# Altium schematic sheet 04fpga-io.SchDoc(04fpga-io)
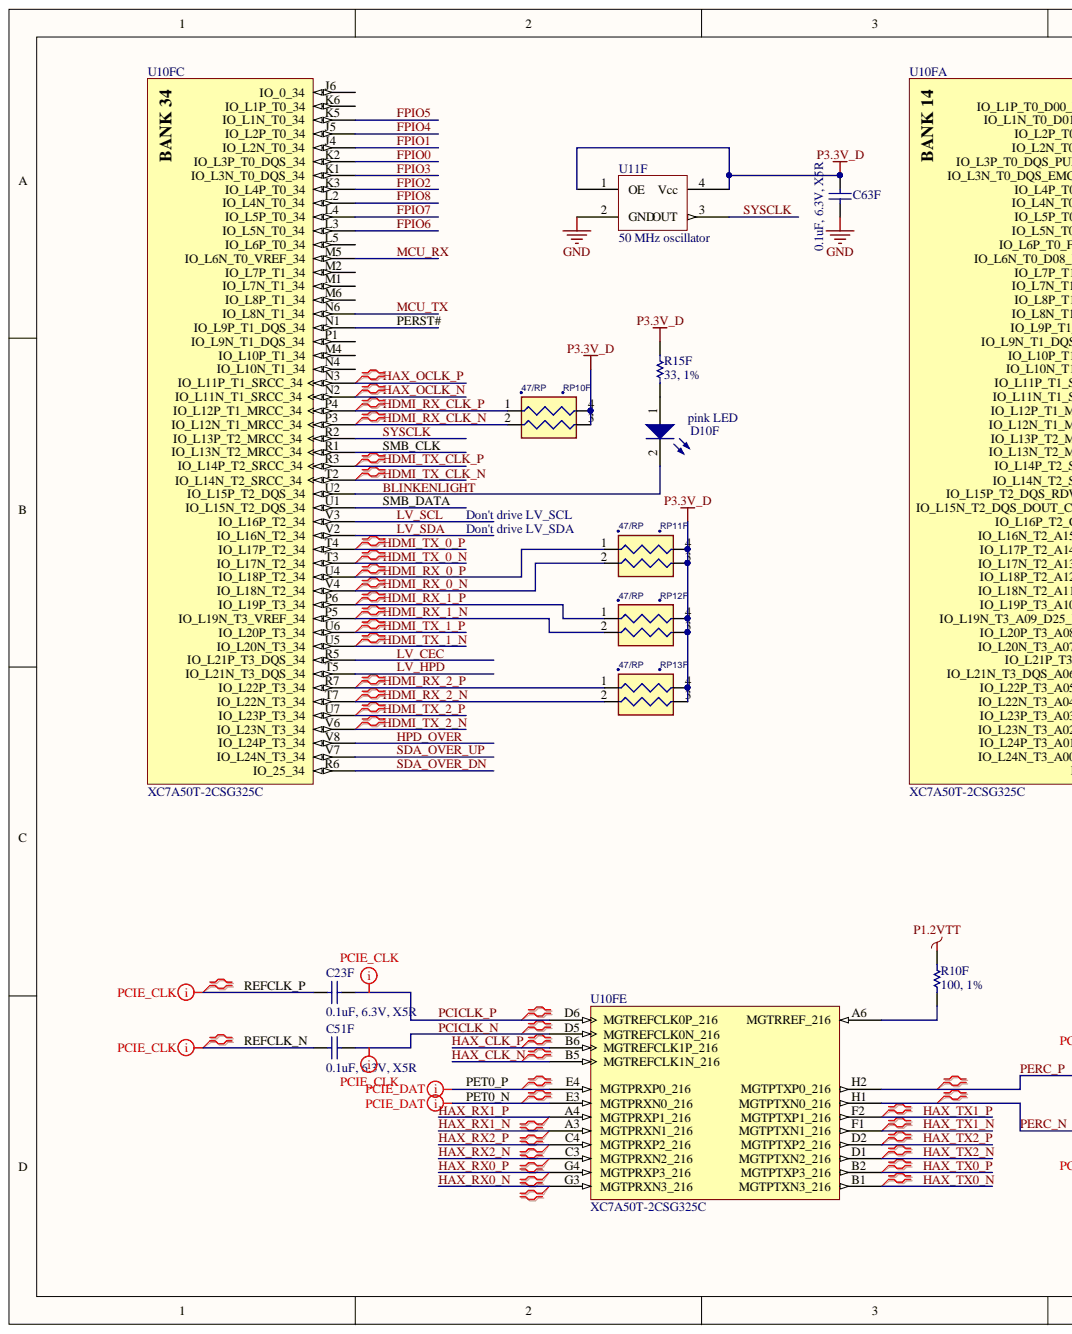
[diagram p0: 04fpga-io.SchDoc, left]
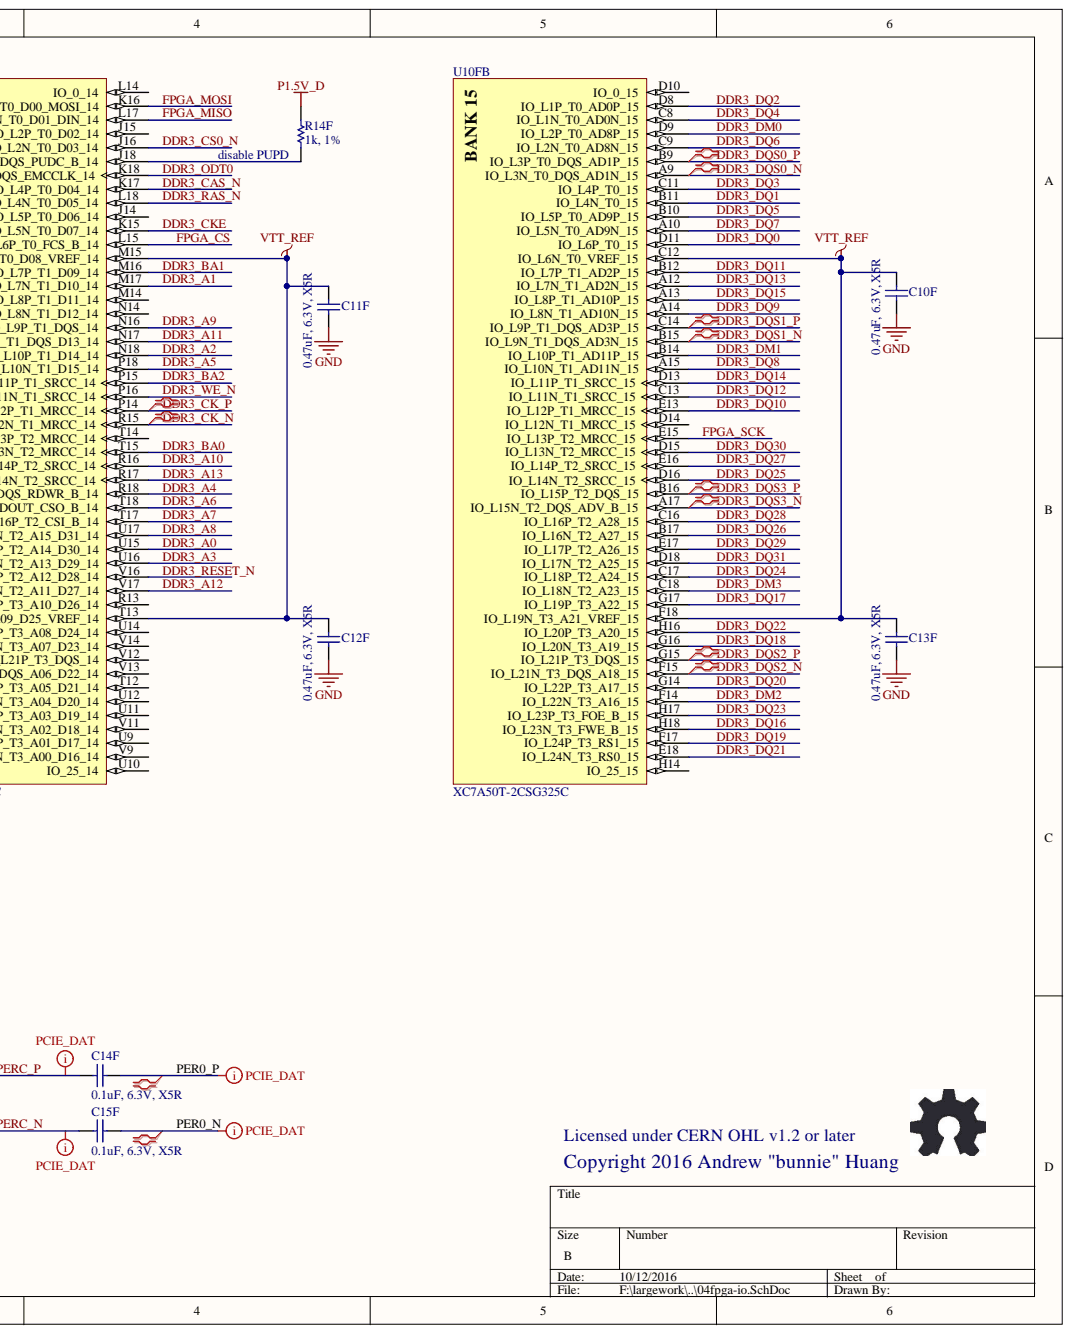
[diagram p1: 04fpga-io.SchDoc, right]

## Parts
C10F  0.47uF, 6.3V, X5R  [CAPC0603X03L]
C11F  0.47uF, 6.3V, X5R  [CAPC0603X03L]
C12F  0.47uF, 6.3V, X5R  [CAPC0603X03L]
C13F  0.47uF, 6.3V, X5R  [CAPC0603X03L]
C14F  0.1uF, 6.3V, X5R  [CAPC0603X03L]
C15F  0.1uF, 6.3V, X5R  [CAPC0603X03L]
C23F  0.1uF, 6.3V, X5R  [CAPC0603X03L]
C51F  0.1uF, 6.3V, X5R  [CAPC0603X03L]
C63F  0.1uF, 6.3V, X5R  [CAPC0603X03L]
D10F  pink LED  [CD2012-0805]
R10F  100, 1%  [RESC1005N]
R14F  1k, 1%  [CAPC0603X03L]
R15F  33, 1%  [RESC1005N]
RP10F  47/RP  [PANASONIC_EXB24V]
RP11F  47/RP  [PANASONIC_EXB24V]
RP12F  47/RP  [PANASONIC_EXB24V]
RP13F  47/RP  [PANASONIC_EXB24V]
U10F  XC7A50T-2CSG325C  [CSG325]  (parts A,B,C,E)
U11F  50 MHz oscillator  [ABRACON_ASDMB]

## Nets
GND: C10F.2 C11F.2 C12F.2 C13F.2 C63F.2 U11F.2(GND)
P1.2VTT: R10F.2
P1.5V_D: R14F.2
P3.3V_D: C63F.1 R15F.2 RP10F.3 RP10F.4 RP11F.3 RP11F.4 RP12F.3 RP12F.4 RP13F.3 RP13F.4 U11F.1(OE) U11F.4(Vcc)
VTT_REF: C10F.1 C11F.1 C12F.1 C13F.1 U10F.C12(IO_L6N_T0_VREF_15) U10F.F18(IO_L19N_T3_A21_VREF_15) U10F.M15(IO_L6N_T0_D08_VREF_14) U10F.T13(IO_L19N_T3_A09_D25_VREF_14)
BLINKENLIGHT: D10F.2 U10F.U2(IO_L15P_T2_DQS_34)
DDR3_A0: U10F.U15(IO_L17P_T2_A14_D30_14)
DDR3_A1: U10F.M17(IO_L7N_T1_D10_14)
DDR3_A2: U10F.N18(IO_L10P_T1_D14_14)
DDR3_A3: U10F.U16(IO_L17N_T2_A13_D29_14)
DDR3_A4: U10F.R18(IO_L15P_T2_DQS_RDWR_B_14)
DDR3_A5: U10F.P18(IO_L10N_T1_D15_14)
DDR3_A6: U10F.T18(IO_L15N_T2_DQS_DOUT_CSO_B_14)
DDR3_A7: U10F.T17(IO_L16P_T2_CSI_B_14)
DDR3_A8: U10F.U17(IO_L16N_T2_A15_D31_14)
DDR3_A9: U10F.N16(IO_L9P_T1_DQS_14)
DDR3_A10: U10F.R16(IO_L14P_T2_SRCC_14)
DDR3_A11: U10F.N17(IO_L9N_T1_DQS_D13_14)
DDR3_A12: U10F.V17(IO_L18N_T2_A11_D27_14)
DDR3_A13: U10F.R17(IO_L14N_T2_SRCC_14)
DDR3_BA0: U10F.T15(IO_L13N_T2_MRCC_14)
DDR3_BA1: U10F.M16(IO_L7P_T1_D09_14)
DDR3_BA2: U10F.P15(IO_L11P_T1_SRCC_14)
DDR3_CAS_N: U10F.K17(IO_L4P_T0_D04_14)
DDR3_CK_N: U10F.R15(IO_L12N_T1_MRCC_14)
DDR3_CK_P: U10F.P14(IO_L12P_T1_MRCC_14)
DDR3_CKE: U10F.K15(IO_L5N_T0_D07_14)
DDR3_CS0_N: U10F.J16(IO_L2N_T0_D03_14)
DDR3_DM0: U10F.D9(IO_L2P_T0_AD8P_15)
DDR3_DM1: U10F.B14(IO_L10P_T1_AD11P_15)
DDR3_DM2: U10F.F14(IO_L22N_T3_A16_15)
DDR3_DM3: U10F.C18(IO_L18N_T2_A23_15)
DDR3_DQ0: U10F.D11(IO_L6P_T0_15)
DDR3_DQ1: U10F.B11(IO_L4N_T0_15)
DDR3_DQ2: U10F.D8(IO_L1P_T0_AD0P_15)
DDR3_DQ3: U10F.C11(IO_L4P_T0_15)
DDR3_DQ4: U10F.C8(IO_L1N_T0_AD0N_15)
DDR3_DQ5: U10F.B10(IO_L5P_T0_AD9P_15)
DDR3_DQ6: U10F.C9(IO_L2N_T0_AD8N_15)
DDR3_DQ7: U10F.A10(IO_L5N_T0_AD9N_15)
DDR3_DQ8: U10F.A15(IO_L10N_T1_AD11N_15)
DDR3_DQ9: U10F.A14(IO_L8N_T1_AD10N_15)
DDR3_DQ10: U10F.E13(IO_L12P_T1_MRCC_15)
DDR3_DQ11: U10F.B12(IO_L7P_T1_AD2P_15)
DDR3_DQ12: U10F.C13(IO_L11N_T1_SRCC_15)
DDR3_DQ13: U10F.A12(IO_L7N_T1_AD2N_15)
DDR3_DQ14: U10F.D13(IO_L11P_T1_SRCC_15)
DDR3_DQ15: U10F.A13(IO_L8P_T1_AD10P_15)
DDR3_DQ16: U10F.H18(IO_L23N_T3_FWE_B_15)
DDR3_DQ17: U10F.G17(IO_L19P_T3_A22_15)
DDR3_DQ18: U10F.G16(IO_L20N_T3_A19_15)
DDR3_DQ19: U10F.F17(IO_L24P_T3_RS1_15)
DDR3_DQ20: U10F.G14(IO_L22P_T3_A17_15)
DDR3_DQ21: U10F.E18(IO_L24N_T3_RS0_15)
DDR3_DQ22: U10F.H16(IO_L20P_T3_A20_15)
DDR3_DQ23: U10F.H17(IO_L23P_T3_FOE_B_15)
DDR3_DQ24: U10F.C17(IO_L18P_T2_A24_15)
DDR3_DQ25: U10F.D16(IO_L14N_T2_SRCC_15)
DDR3_DQ26: U10F.B17(IO_L16N_T2_A27_15)
DDR3_DQ27: U10F.E16(IO_L14P_T2_SRCC_15)
DDR3_DQ28: U10F.C16(IO_L16P_T2_A28_15)
DDR3_DQ29: U10F.E17(IO_L17P_T2_A26_15)
DDR3_DQ30: U10F.D15(IO_L13N_T2_MRCC_15)
DDR3_DQ31: U10F.D18(IO_L17N_T2_A25_15)
DDR3_DQS0_N: U10F.A9(IO_L3N_T0_DQS_AD1N_15)
DDR3_DQS0_P: U10F.B9(IO_L3P_T0_DQS_AD1P_15)
DDR3_DQS1_N: U10F.B15(IO_L9N_T1_DQS_AD3N_15)
DDR3_DQS1_P: U10F.C14(IO_L9P_T1_DQS_AD3P_15)
DDR3_DQS2_N: U10F.F15(IO_L21N_T3_DQS_A18_15)
DDR3_DQS2_P: U10F.G15(IO_L21P_T3_DQS_15)
DDR3_DQS3_N: U10F.A17(IO_L15N_T2_DQS_ADV_B_15)
DDR3_DQS3_P: U10F.B16(IO_L15P_T2_DQS_15)
DDR3_ODT0: U10F.K18(IO_L3N_T0_DQS_EMCCLK_14)
DDR3_RAS_N: U10F.L18(IO_L4N_T0_D05_14)
DDR3_RESET_N: U10F.V16(IO_L18P_T2_A12_D28_14)
DDR3_WE_N: U10F.P16(IO_L11N_T1_SRCC_14)
FPGA_CS: U10F.L15(IO_L6P_T0_FCS_B_14)
FPGA_MISO: U10F.L17(IO_L1N_T0_D01_DIN_14)
FPGA_MOSI: U10F.K16(IO_L1P_T0_D00_MOSI_14)
FPGA_SCK: U10F.E15(IO_L13P_T2_MRCC_15)
FPIO0: U10F.K2(IO_L3P_T0_DQS_34)
FPIO1: U10F.J4(IO_L2N_T0_34)
FPIO2: U10F.K3(IO_L4P_T0_34)
FPIO3: U10F.K1(IO_L3N_T0_DQS_34)
FPIO4: U10F.J5(IO_L2P_T0_34)
FPIO5: U10F.K5(IO_L1N_T0_34)
FPIO6: U10F.L3(IO_L5N_T0_34)
FPIO7: U10F.L4(IO_L5P_T0_34)
FPIO8: U10F.L2(IO_L4N_T0_34)
HAX_CLK_N: U10F.B5(MGTREFCLK1N_216)
HAX_CLK_P: U10F.B6(MGTREFCLK1P_216)
HAX_OCLK_N: U10F.N2(IO_L11N_T1_SRCC_34)
HAX_OCLK_P: U10F.N3(IO_L11P_T1_SRCC_34)
HAX_RX0_N: U10F.G3(MGTPRXN3_216)
HAX_RX0_P: U10F.G4(MGTPRXP3_216)
HAX_RX1_N: U10F.A3(MGTPRXN1_216)
HAX_RX1_P: U10F.A4(MGTPRXP1_216)
HAX_RX2_N: U10F.C3(MGTPRXN2_216)
HAX_RX2_P: U10F.C4(MGTPRXP2_216)
HAX_TX0_N: U10F.B1(MGTPTXN3_216)
HAX_TX0_P: U10F.B2(MGTPTXP3_216)
HAX_TX1_N: U10F.F1(MGTPTXN1_216)
HAX_TX1_P: U10F.F2(MGTPTXP1_216)
HAX_TX2_N: U10F.D1(MGTPTXN2_216)
HAX_TX2_P: U10F.D2(MGTPTXP2_216)
HDMI_RX_0_N: RP11F.2 U10F.V4(IO_L18N_T2_34)
HDMI_RX_0_P: RP11F.1 U10F.U4(IO_L18P_T2_34)
HDMI_RX_1_N: RP12F.2 U10F.P5(IO_L19N_T3_VREF_34)
HDMI_RX_1_P: RP12F.1 U10F.P6(IO_L19P_T3_34)
HDMI_RX_2_N: RP13F.2 U10F.T7(IO_L22N_T3_34)
HDMI_RX_2_P: RP13F.1 U10F.R7(IO_L22P_T3_34)
HDMI_RX_CLK_N: RP10F.2 U10F.P3(IO_L12N_T1_MRCC_34)
HDMI_RX_CLK_P: RP10F.1 U10F.P4(IO_L12P_T1_MRCC_34)
HDMI_TX_0_N: U10F.T3(IO_L17N_T2_34)
HDMI_TX_0_P: U10F.T4(IO_L17P_T2_34)
HDMI_TX_1_N: U10F.U5(IO_L20N_T3_34)
HDMI_TX_1_P: U10F.U6(IO_L20P_T3_34)
HDMI_TX_2_N: U10F.V6(IO_L23N_T3_34)
HDMI_TX_2_P: U10F.U7(IO_L23P_T3_34)
HDMI_TX_CLK_N: U10F.T2(IO_L14N_T2_SRCC_34)
HDMI_TX_CLK_P: U10F.R3(IO_L14P_T2_SRCC_34)
HPD_OVER: U10F.V8(IO_L24P_T3_34)
LV_CEC: U10F.R5(IO_L21P_T3_DQS_34)
LV_HPD: U10F.T5(IO_L21N_T3_DQS_34)
LV_SCL: U10F.V3(IO_L16P_T2_34)
LV_SDA: U10F.V2(IO_L16N_T2_34)
MCU_RX: U10F.M5(IO_L6N_T0_VREF_34)
MCU_TX: U10F.N6(IO_L8N_T1_34)
PCICLK_N: C51F.1 U10F.D5(MGTREFCLK0N_216)
PCICLK_P: C23F.1 U10F.D6(MGTREFCLK0P_216)
PER0_N: C15F.1
PER0_P: C14F.1
PERC_N: C15F.2 U10F.H1(MGTPTXN0_216)
PERC_P: C14F.2 U10F.H2(MGTPTXP0_216)
PERST#: U10F.N1(IO_L9P_T1_DQS_34)
PET0_N: U10F.E3(MGTPRXN0_216)
PET0_P: U10F.E4(MGTPRXP0_216)
REFCLK_N: C51F.2
REFCLK_P: C23F.2
SDA_OVER_DN: U10F.R6(IO_25_34)
SDA_OVER_UP: U10F.V7(IO_L24N_T3_34)
SMB_CLK: U10F.R1(IO_L13N_T2_MRCC_34)
SMB_DATA: U10F.U1(IO_L15N_T2_DQS_34)
SYSCLK: U10F.R2(IO_L13P_T2_MRCC_34) U11F.3(OUT)
NetD10F_1: D10F.1 R15F.1
NetR10F_1: R10F.1 U10F.A6(MGTRREF_216)
NetR14F_1: R14F.1 U10F.J18(IO_L3P_T0_DQS_PUDC_B_14)

Not connected: U10F D10(IO_0_15) D14(IO_L12N_T1_MRCC_15) H14(IO_25_15) J6(IO_0_34) J14(IO_L5P_T0_D06_14) J15(IO_L2P_T0_D02_14) K6(IO_L1P_T0_34) L5(IO_L6P_T0_34) L14(IO_0_14) M1(IO_L7N_T1_34) M2(IO_L7P_T1_34) M4(IO_L10P_T1_34) M6(IO_L8P_T1_34) M14(IO_L8P_T1_D11_14) N4(IO_L10N_T1_34) N14(IO_L8N_T1_D12_14) P1(IO_L9N_T1_DQS_34) R13(IO_L19P_T3_A10_D26_14) T12(IO_L22P_T3_A05_D21_14) T14(IO_L13P_T2_MRCC_14) U9(IO_L24P_T3_A01_D17_14) U10(IO_25_14) U11(IO_L23P_T3_A03_D19_14) U12(IO_L22N_T3_A04_D20_14) U14(IO_L20P_T3_A08_D24_14) V9(IO_L24N_T3_A00_D16_14) V11(IO_L23N_T3_A02_D18_14) V12(IO_L21P_T3_DQS_14) V13(IO_L21N_T3_DQS_A06_D22_14) V14(IO_L20N_T3_A07_D23_14)

## Library data of the parts
C10F C11F C12F C13F = Cap Semi: Capacitor (Semiconductor SIM Model); MPN: CL03A474KQ3NNNH; MYPN: C-474-6p3-X5R-0201; VENDOR: Samsung; Component Kind: Standard; Library Name: Miscellaneous Devices.IntLib; Signal Integrity: Cap Semi; Simulation: CAP
C14F C15F C23F C51F C63F = Cap Semi: Capacitor (Semiconductor SIM Model); MPN: CL03A104KQ3NNNC; MYPN: C-104-6p3-X5R-0201; VENDOR: Samsung; Component Kind: Standard; Library Name: Miscellaneous Devices.IntLib; Signal Integrity: Cap Semi; Simulation: CAP
D10F = LED2: Typical RED, GREEN, YELLOW, AMBER GaAs LED; MPN: CB-2012QFC-P8; MYPN: D-LED-PINK1-0805; VENDOR: Citybright; Component Kind: Standard; LatestRevisionDate: 17-Jul-2002; LatestRevisionNote: Re-released for DXP Platform.; Library Name: Miscellaneous Devices.IntLib; PackageReference: 3.2X1.6X1.1; PackageVersion: Feb-2002; Published: 8-Jun-2000; Simulation: LED2; Publisher: Altium Limited
R10F = Res1: Resistor; MPN: ANY; MYPN: R-101-1P-1_16W-0402; Component Kind: Standard; Library Name: Miscellaneous Devices.IntLib; Signal Integrity: Res; Simulation: RESISTOR; VENDOR: ANY
R14F = Res1: Resistor; MPN: ANY; MYPN: R-102-1P-1_16W-0201; Component Kind: Standard; Library Name: Miscellaneous Devices.IntLib; Signal Integrity: Res; Simulation: RESISTOR; VENDOR: ANY
R15F = Res1: Resistor; MPN: ANY; MYPN: R-330-1P-1_16W-0402; Component Kind: Standard; Library Name: Miscellaneous Devices.IntLib; Signal Integrity: Res; Simulation: RESISTOR; VENDOR: ANY
RP10F RP11F RP12F RP13F = RPACK2: MPN: RP102PJ470CS; MFG: SAMSUNG; MYPN: RP-RP102PJ470CS; Component Kind: Standard; Signal Integrity: Model Name
U10F = XC7A35T-2CSG325C: Artix-7 FPGA, 150 User I/Os, 4 GTP, 324-Ball BGA, Speed Grade 2, Commercial Grade, Pb-Free; Available User I/O: 150; Clock Mgmt Tiles (CMTs): 5; GTP: 4; Logic Cells: 33280; MYPN: U-XC7A50T-2CSG325C; Speed Grades: 2; Total Block RAM: 1800 Kb; VENDOR: Xilinx; Component Kind: Standard; ComponentLink1Description: Manufacturer URL; ComponentLink1URL: http://www.xilinx.com/; ComponentLink2Description: Datasheet; ComponentLink2URL: http://www.xilinx.com/support/documentation/data_sheets/ds180_7Series_Overview.pdf; ComponentLink3Description: Package Specification; ComponentLink3URL: http://www.xilinx.com/support/documentation/user_guides/ug475_7Series_Pkg_Pinout.pdf; ComponentLink4Description: DC and Switching Characteristics; ComponentLink4URL: http://www.xilinx.com/support/documentation/data_sheets/ds181_Artix_7_Data_Sheet.pdf; ComponentLink5Description: Package File; ComponentLink5URL: http://www.xilinx.com/support/packagefiles/a7packages/xc7a200tsbg484pkg.txt; ComponentLink6Description: Packaging and Pinout; ComponentLink6URL: http://www.xilinx.com/support/documentation/user_guides/ug475_7Series_Pkg_Pinout.pdf; DatasheetVersion: v1.15; Library Name: Xilinx Artix-7.IntLib; Maximum Distributed RAM: 400 Kb; Mounting Technology: Surface Mount; MPN: XC7A50T-2CSG325C; NexusDeviceID: XC7A35T-2CSG325C; Operating Temperature Range: Commercial; PackageDescription: 324-Ball Wire-Bond Chip-Scale BGA, Body 15 x 15 mm, Pitch 0.8 mm; PackageReference: CSG-325; PackageVersion: Rev. 1.11
U11F = OSCILLATOR: Abracon ASDMB Miniature Oscillator; MPN: ASDMB-50.000MHZ-LC-T; MYPN: U-ASDMB-50MHz; VENDOR: Abracon LLC; Component Kind: Standard; Library Name: commonsch.lib
